# Altium schematic sheet W702Dev.SchDoc
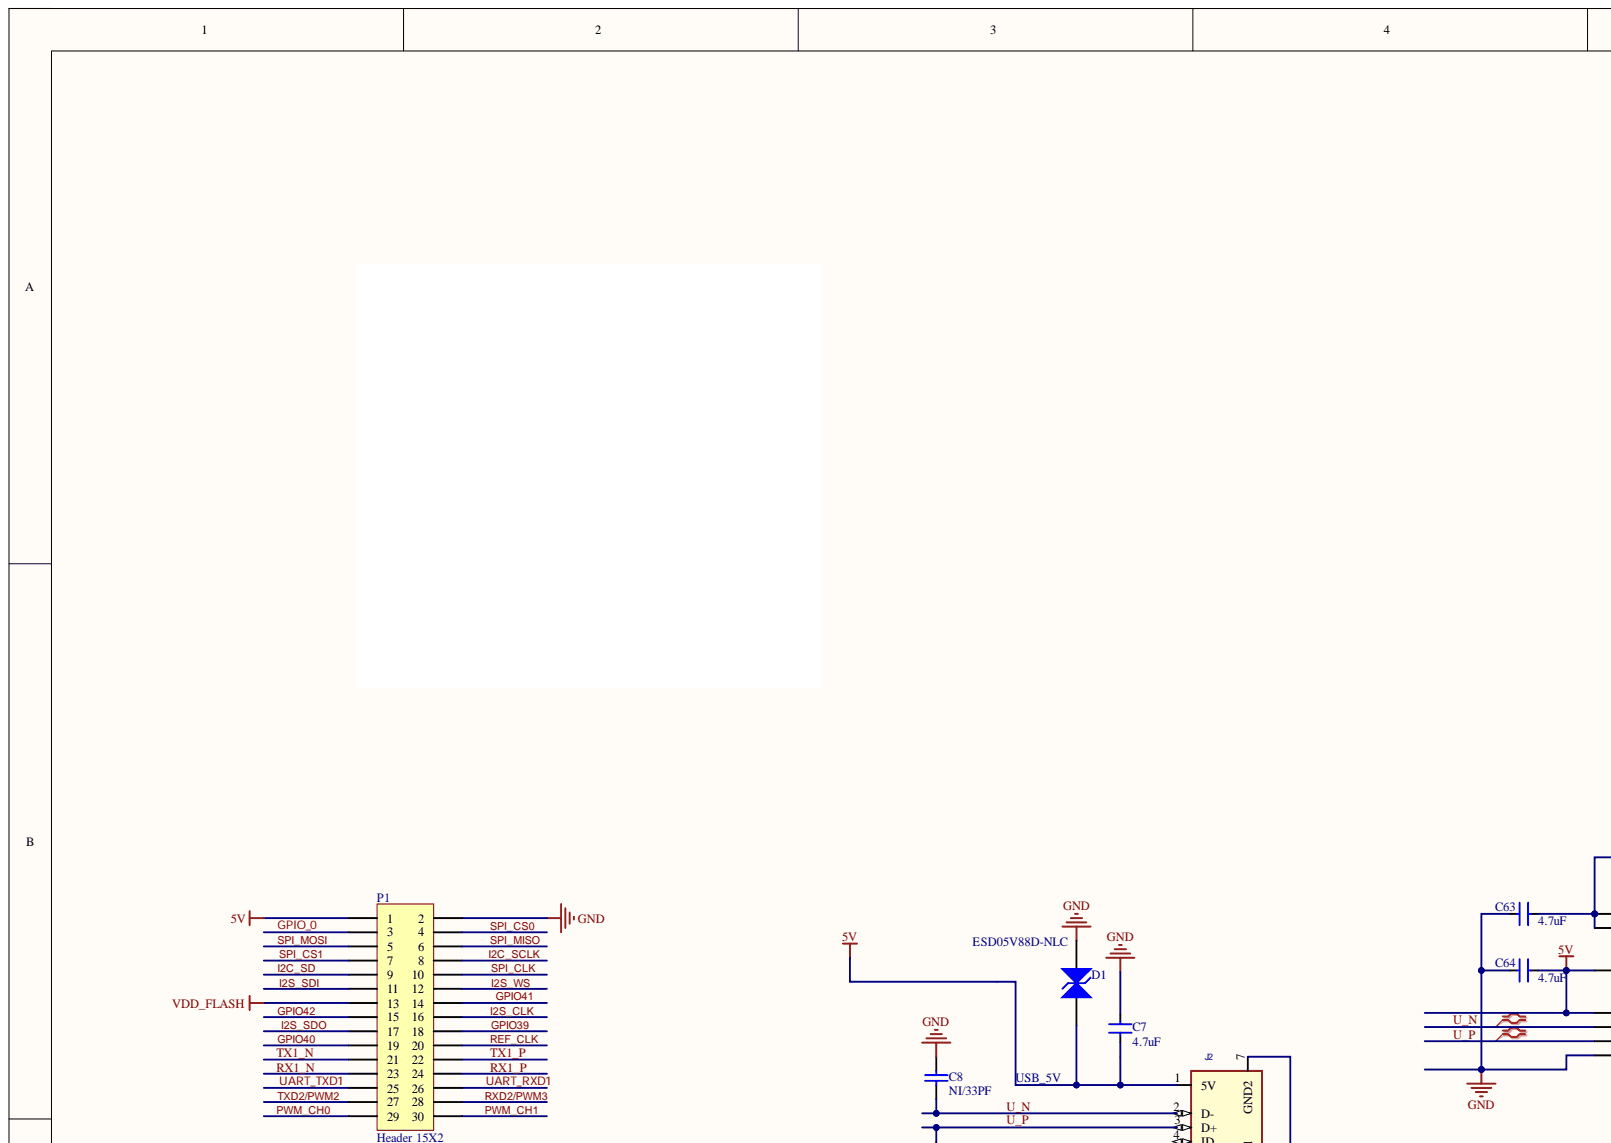
[diagram p0: W702Dev.SchDoc, top left]
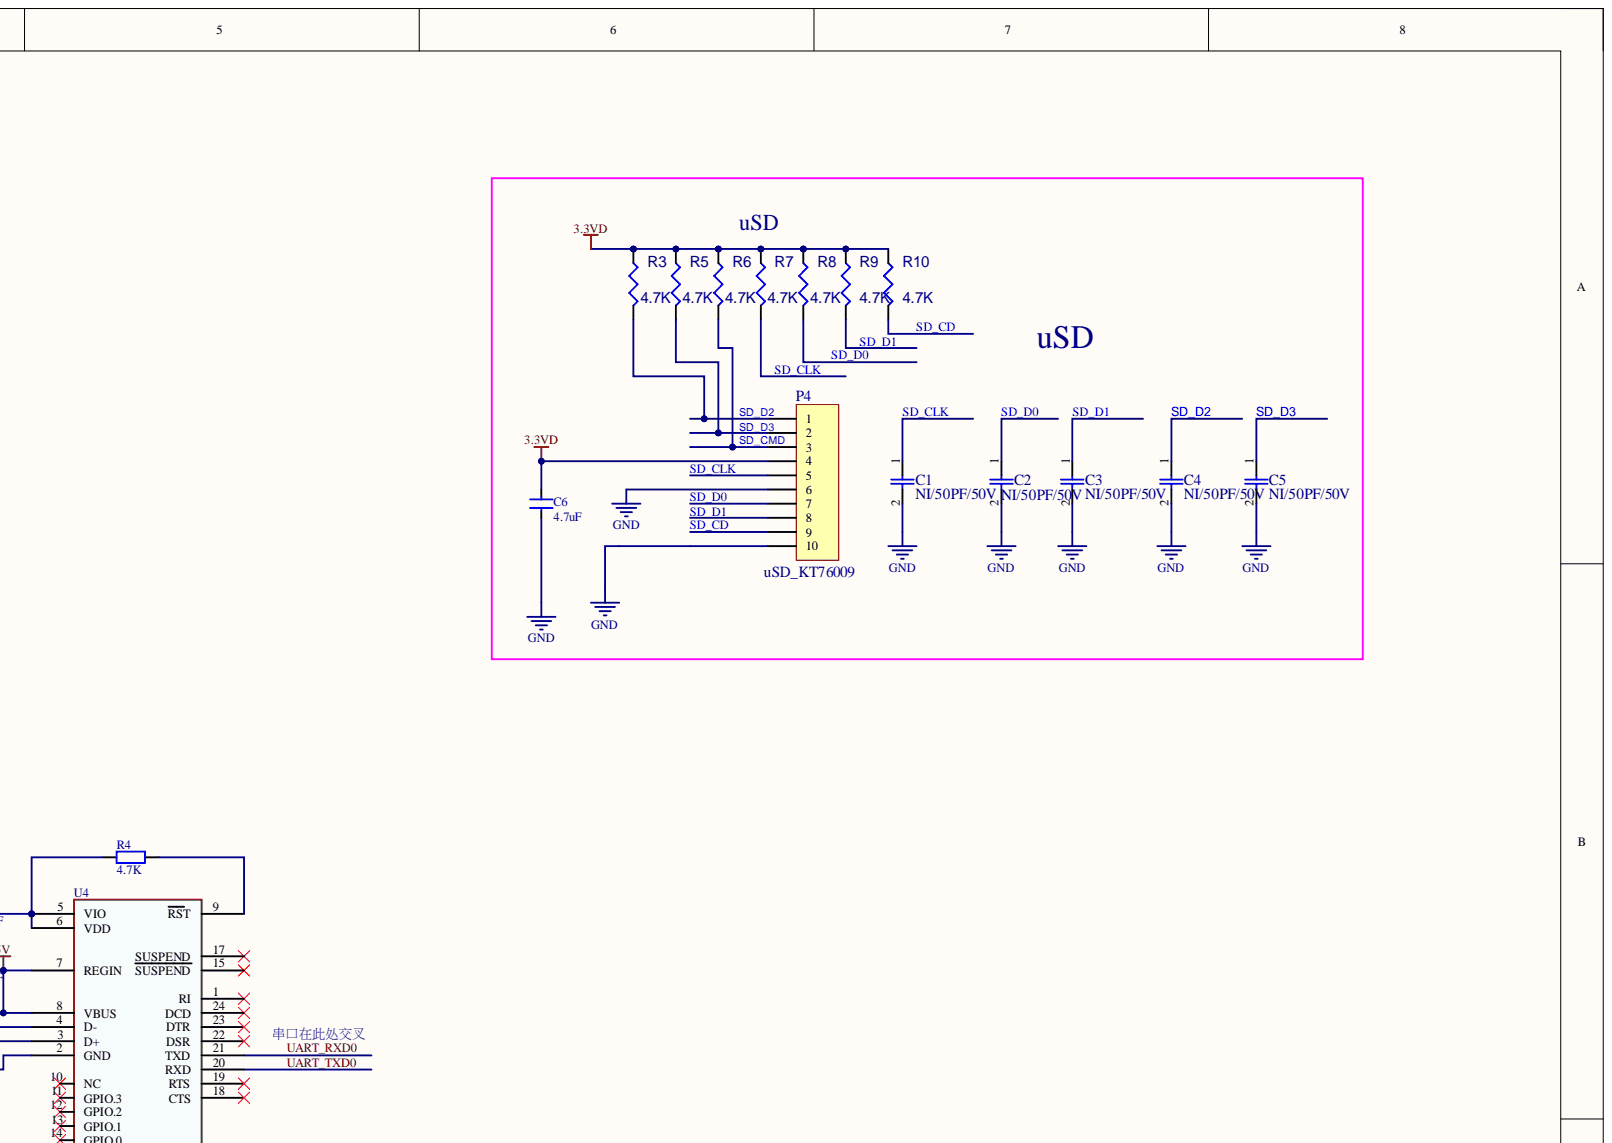
[diagram p1: W702Dev.SchDoc, top right]
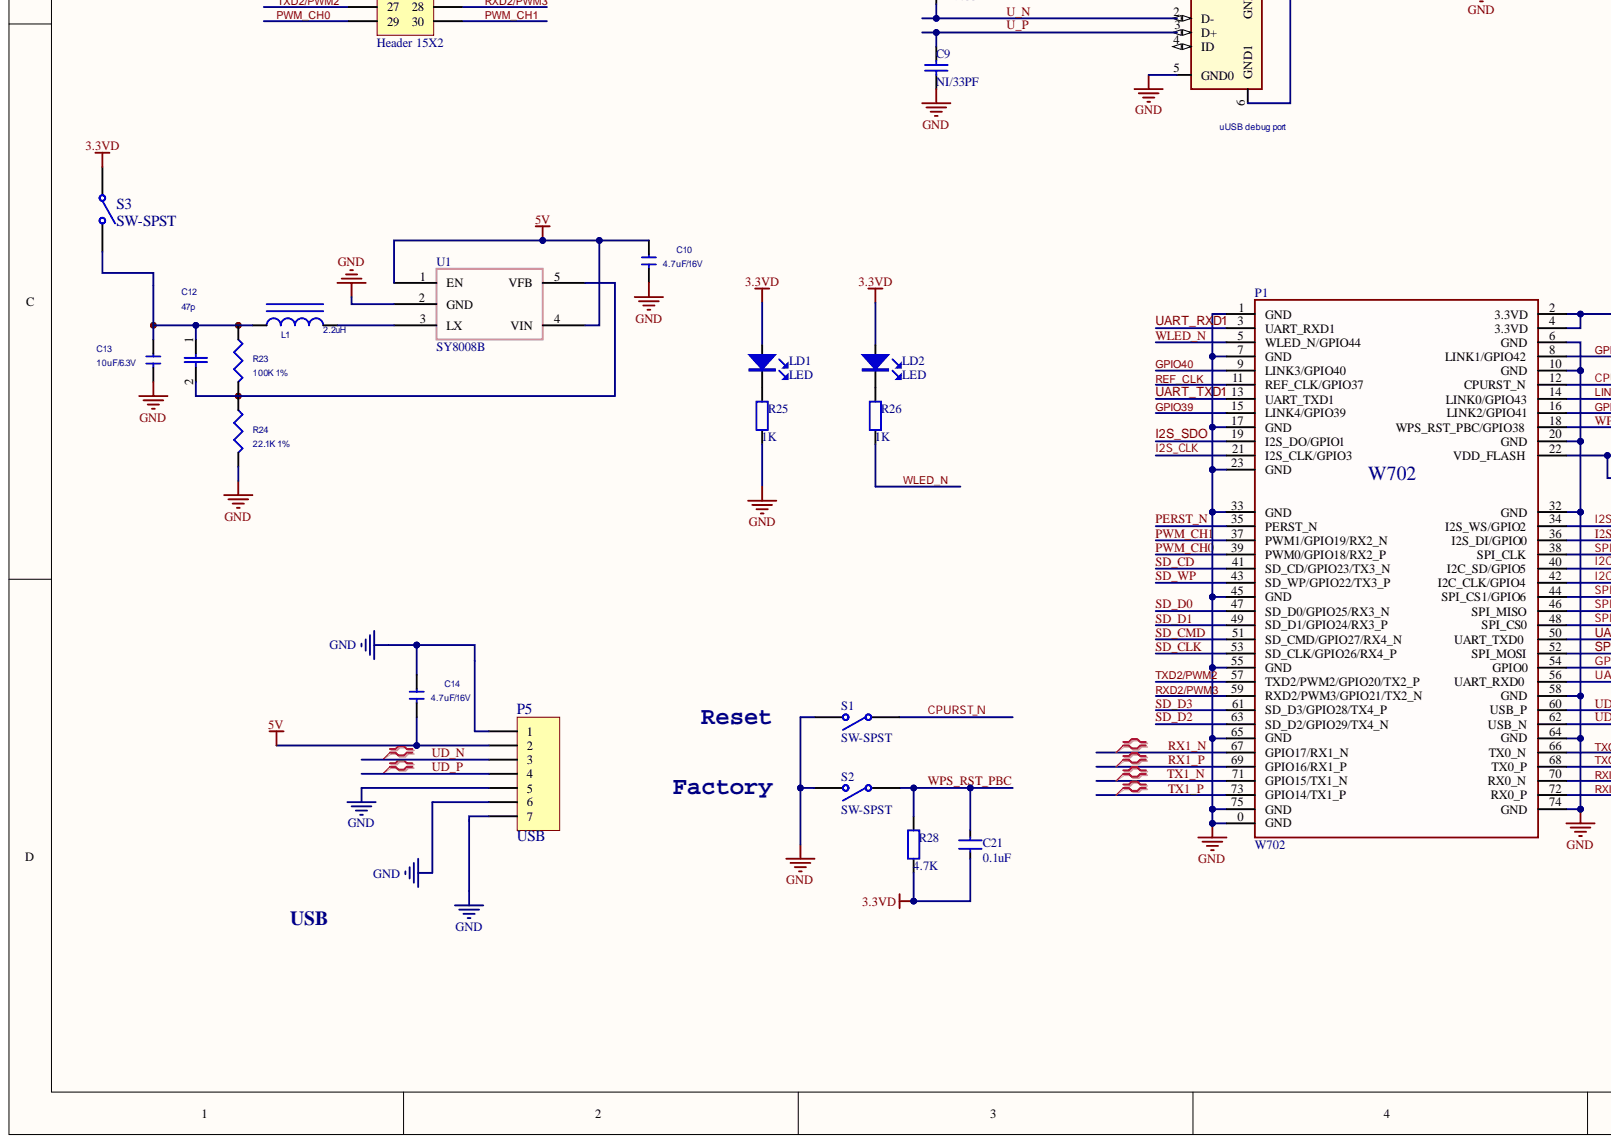
[diagram p2: W702Dev.SchDoc, bottom left]
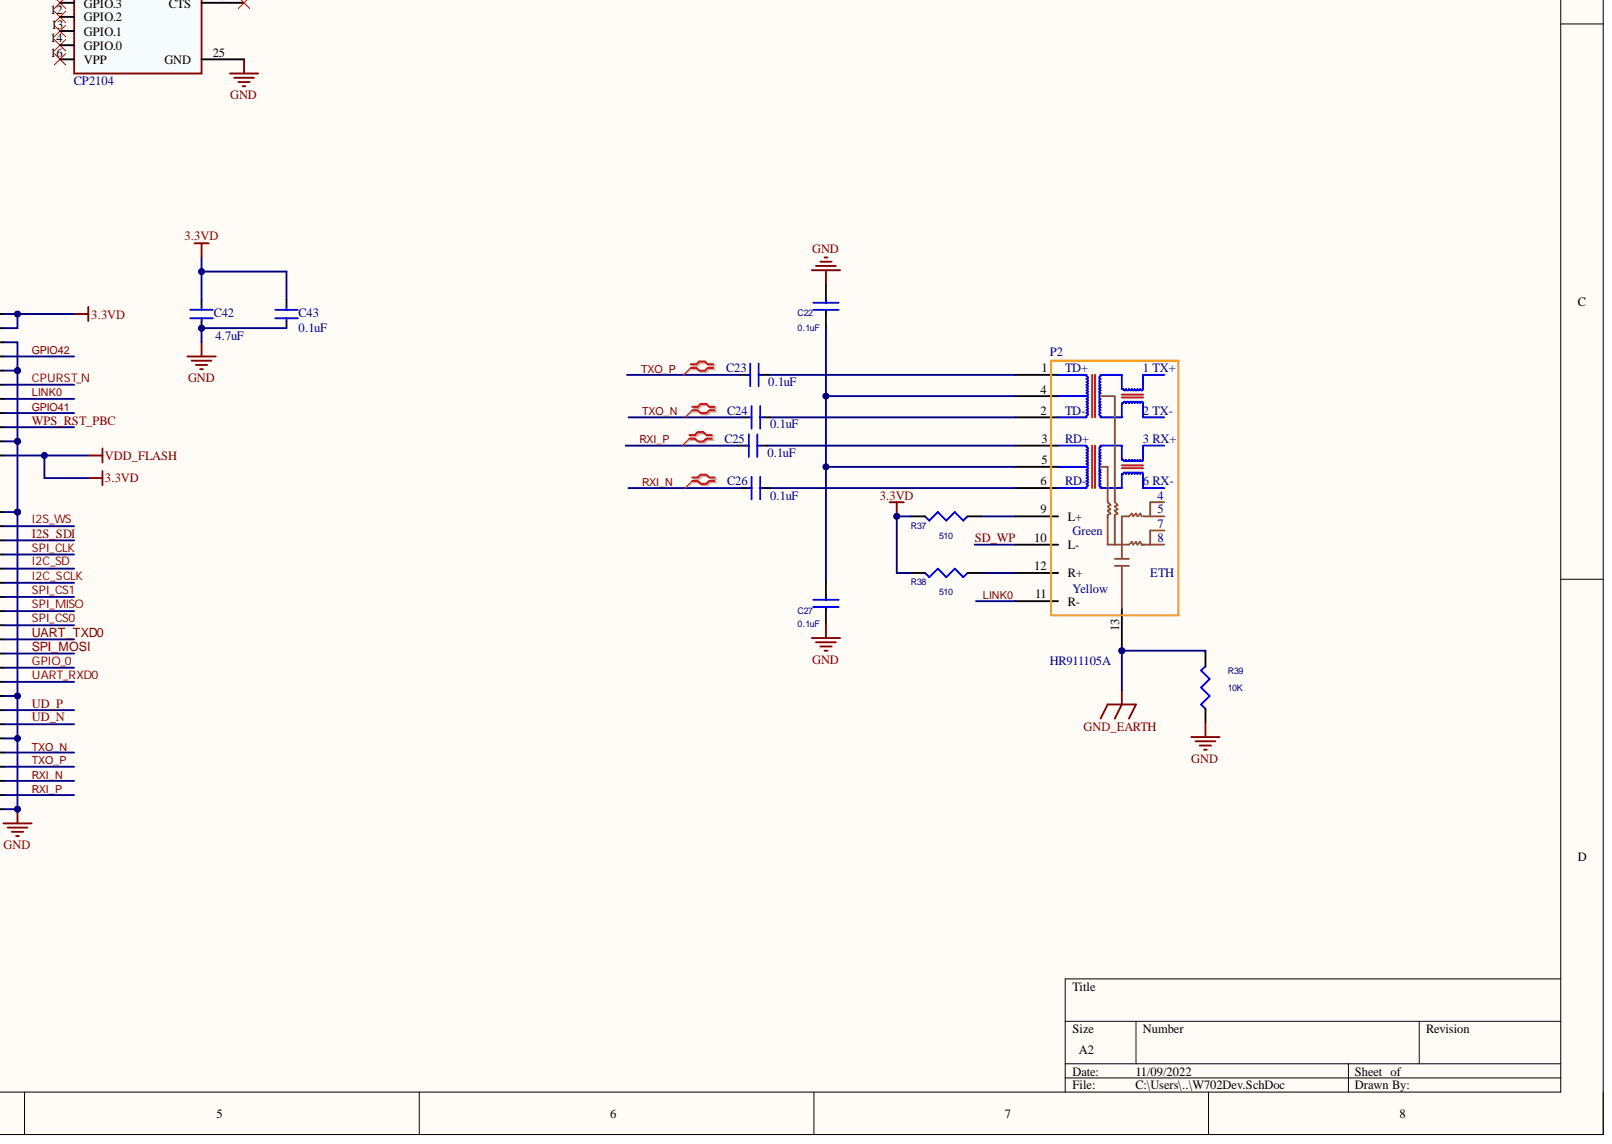
[diagram p3: W702Dev.SchDoc, bottom right]

## Parts
C1  NI/50PF/50V  [C0402]
C2  NI/50PF/50V  [C0402]
C3  NI/50PF/50V  [C0402]
C4  NI/50PF/50V  [C0402]
C5  NI/50PF/50V  [C0402]
C6  4.7uF  [C0402]
C7  4.7uF  [C0402]
C8  NI/33PF  [C0402]
C9  NI/33PF  [C0402]
C10  4.7uF/16V  [C0603]
C12  47p  [C0402]
C13  10uF/6.3V  [C0603]
C14  4.7uF/16V  [C0603]
C21  0.1uF  [C0402]
C22  0.1uF  [C0402]
C23  0.1uF  [C0402]
C24  0.1uF  [C0402]
C25  0.1uF  [C0402]
C26  0.1uF  [C0402]
C27  0.1uF  [C0402]
C42  4.7uF  [C0402]
C43  0.1uF  [C0402]
C63  4.7uF  [C0402]
C64  4.7uF  [C0402]
D1  ESD05V88D-NLC  [D0034]
J2  uUSB debug port  [microUSB]
L1  2.2uH  [l2512]
LD1  LED  [LED_0603]
LD2  LED  [LED_0603]
P1  Header 15X2  [HDR2X15]
P1  W702
P2  HR911105A  [P0029]
P4  uSD_KT76009  [uSD_KT76009]
P5  USB  [USB A母 90度側插]
R3  4.7K  [R0402]
R4  4.7K  [R0402]
R5  4.7K  [R0402]
R6  4.7K  [R0402]
R7  4.7K  [R0402]
R8  4.7K  [R0402]
R9  4.7K  [R0402]
R10  4.7K  [R0402]
R23  100K 1%  [R0402]
R24  22.1K 1%  [R0402]
R25  1K  [R0402]
R26  1K  [R0402]
R28  4.7K  [R0402]
R37  510  [R0402]
R38  510  [R0402]
R39  10K  [R0402]
S1  SW-SPST  [P0066]
S2  SW-SPST  [P0066]
S3  SW-SPST  [按件開關2PIN_DIP]
U1  SY8008B  [SOT23-5]
U4  CP2104  [QFN24]

## Nets
3.3VD: C6.1 C21.1 C42.1 C43.1 LD1.A LD2.A P1.2 P1.4 P1.13 P1.22 P4.4 R3.1 R5.1 R6.1 R7.1 R8.1 R9.1 R10.1 R28.2 R37.2 R38.2 S3.1
GND: C1.2 C2.2 C3.2 C4.2 C5.2 C6.2 C7.1 C8.1 C9.1 C10.2 C13.2 C14.1 C22.2 C27.1 C42.2 C43.2 C63.2 C64.1 D1.1 J2.5(GND0) P1.0(GND) P1.1 P1.2 P1.6 P1.7 P1.10 P1.17 P1.20 P1.23 P1.32(GND) P1.33(GND) P1.45(GND) P1.55(GND) P1.58(GND) P1.64(GND) P1.65(GND) P1.74(GND) P1.75(GND) P4.6 P4.10 P5.1 P5.5 P5.6 P5.7 R24.2 R25.2 R39.1 S1.2 S2.2 U1.2(GND) U4.2(GND) U4.25(GND)
GND_EARTH: P2.13 R39.2
CPURST_N: P1.12 S1.1
GPIO39: P1.15 P1.18
GPIO40: P1.9 P1.19
GPIO41: P1.14 P1.16
GPIO42: P1.8 P1.15
GPIO_0: P1.3 P1.54(GPIO0)
I2C_SCLK: P1.8 P1.42(I2C_CLK/GPIO4)
I2C_SD: P1.9 P1.40(I2C_SD/GPIO5)
I2S_CLK: P1.16 P1.21
I2S_SDI: P1.11 P1.36(I2S_DI/GPIO0)
I2S_SDO: P1.17 P1.19
I2S_WS: P1.12 P1.34(I2S_WS/GPIO2)
LINK0: P1.14 P2.11(R-)
PERST_N: P1.35(PERST_N)
PWM_CH0: P1.29 P1.39(PWM0/GPIO18/RX2_P)
PWM_CH1: P1.30 P1.37(PWM1/GPIO19/RX2_N)
REF_CLK: P1.11 P1.20
RX1_N: P1.23 P1.67(GPIO17/RX1_N)
RX1_P: P1.24 P1.69(GPIO16/RX1_P)
RXD2/PWM3: P1.28 P1.59(RXD2/PWM3/GPIO21/TX2_N)
RXI_N: C26.1 P1.70(RX0_N)
RXI_P: C25.1 P1.72(RX0_P)
SD_CD: P1.41(SD_CD/GPIO23/TX3_N) P4.9 R10.2
SD_CLK: C1.1 P1.53(SD_CLK/GPIO26/RX4_P) P4.5 R7.2
SD_CMD: P1.51(SD_CMD/GPIO27/RX4_N) P4.3 R6.2
SD_D0: C2.1 P1.47(SD_D0/GPIO25/RX3_N) P4.7 R8.2
SD_D1: C3.1 P1.49(SD_D1/GPIO24/RX3_P) P4.8 R9.2
SD_D2: C4.1 P1.63(SD_D2/GPIO29/TX4_N) P4.1 R3.2
SD_D3: C5.1 P1.61(SD_D3/GPIO28/TX4_P) P4.2 R5.2
SD_WP: P1.43(SD_WP/GPIO22/TX3_P) P2.10(L-)
SPI_CLK: P1.10 P1.38(SPI_CLK)
SPI_CS0: P1.4 P1.48(SPI_CS0)
SPI_CS1: P1.7 P1.44(SPI_CS1/GPIO6)
SPI_MISO: P1.6 P1.46(SPI_MISO)
SPI_MOSI: P1.5 P1.52(SPI_MOSI)
TX1_N: P1.21 P1.71(GPIO15/TX1_N)
TX1_P: P1.22 P1.73(GPIO14/TX1_P)
TXD2/PWM2: P1.27 P1.57(TXD2/PWM2/GPIO20/TX2_P)
TXO_N: C24.1 P1.66(TX0_N)
TXO_P: C23.1 P1.68(TX0_P)
U_N: C8.2 J2.2(D-) U4.4(D-)
U_P: C9.2 J2.3(D+) U4.3(D+)
UART_RXD0: P1.56(UART_RXD0) U4.21(TXD)
UART_RXD1: P1.3 P1.26
UART_TXD0: P1.50(UART_TXD0) U4.20(RXD)
UART_TXD1: P1.13 P1.25
UD_N: P1.62(USB_N) P5.3
UD_P: P1.60(USB_P) P5.4
USB_5V: C7.2 C10.1 C14.2 C64.2 D1.2 J2.1(5V) P1.1 P5.2 U1.1(EN) U1.4(VIN) U4.7(REGIN) U4.8(VBUS)
WLED_N: P1.5 R26.2
WPS_RST_PBC: C21.2 P1.18 R28.1 S2.1
NetC12_1: C12.1 C13.1 L1.1 R23.1 S3.2
NetC12_2: C12.2 R23.2 R24.1 U1.5(VFB)
NetC22_1: C22.1 C27.2 P2.4 P2.5
NetC23_2: C23.2 P2.1
NetC24_2: C24.2 P2.2
NetC25_2: C25.2 P2.3
NetC26_2: C26.2 P2.6
NetC63_1: C63.1 R4.1 U4.5(VIO) U4.6(VDD)
NetJ2_6: J2.6(GND1) J2.7(GND2)
NetL1_2: L1.2 U1.3(LX)
NetLD1_K: LD1.K R25.1
NetLD2_K: LD2.K R26.1
NetP2_9: P2.9(L+) R37.1
NetP2_12: P2.12(R+) R38.1
NetR4_2: R4.2 U4.9(RST)

Not connected: J2 4(ID); U4 1(RI) 10(NC) 11(GPIO.3) 12(GPIO.2) 13(GPIO.1) 14(GPIO.0) 15(SUSPEND) 16(VPP) 17(SUSPEND) 18(CTS) 19(RTS) 22(DSR) 23(DTR) 24(DCD)

## Library data of the parts
C1-C5 = C0402: Capacitor; Component Kind: Standard
C6 C7 C42 C63 C64 = CAP: 普通小电容; 料號: C0023; Component Kind: Standard
C8 C9 = CAP_1: Capacitor; Value: 100pF; Component Kind: Standard; Published: 8-Jun-2000; Revision: July-2002: Re-released for DXP Platform.; Signal Integrity: Cap; Simulation: CAP; Publisher: Altium Limited
C10 C14 = MLCC 22uF 6_1.3V 20% 0603 SMD: 料號: C0076; Component Kind: Standard
C12 = CAP/0402_1: 料號: C0065; Component Kind: Standard
C13 = MLCC 22uF 6_1.3V 20% 0603 SMD: 料號: C0025; Component Kind: Standard
C21 C23-C26 C43 = CAP: 普通小电容; 料號: C0006; Component Kind: Standard
C22 C27 = CAPACITOR NON-POL: 料號: C0006; Component Kind: Standard
D1 = D0034: bidirectional, 5V, 1.0*0.6, 0.25pF; Component Kind: Standard; ComponentLink1Description: 供应商链接; ComponentLink1URL: http://www.szlcsc.com/product/details_79530.html; Library Name: D0034.SchLib; Package: SMB/DO-214AA; Supplier: LC; SuppliersPartNumber: C78395; 料號: D0034
J2 = CONN Micro USB SMD: Part number: 10B119650501; 料號: P0009; Component Kind: Standard
L1 = IND2.2uH/1KHZ 1.8A 20% 3D18 SMD: 料號: L0010; Component Kind: Standard
LD1 LD2 = LED: Light-Emitting Diode; 料號: D0018; Component Kind: Standard; Library Name: ST_Lib3D.SchLib
P1 = Header 15X2: Header, 15-Pin, Dual row; Component Kind: Standard; LatestRevisionDate: 17-Jul-2002; LatestRevisionNote: Re-released for DXP Platform.; Library Name: LIB_MANGO.IntLib; Publisher: Altium Limited
P4 = Header 10: Component Kind: Standard; Description: uSD_KT76009; LatestRevisionDate: 17-Jul-2002; LatestRevisionNote: Re-released for DXP Platform.; Library Name: Miscellaneous Connectors.IntLib; Signal Integrity: Connector
P5 = Header 7: USB A TYPE, 7-Pin; 料號: P0055; Component Kind: Standard; LatestRevisionNote: Re-released for DXP Platform.; Library Name: Miscellaneous Connectors.IntLib; Publisher: Altium Limited
R3 R5-R10 = RES 1K 1% 1/16W 0402 SMD: Resistor; 料號: R0007; Component Kind: Standard; PCB DECAL: R0402; Value: 4.7K
R4 R28 = RES: 普通电阻; 料號: R0007; Component Kind: Standard
R23 = RES 220K 5% 1/16W 0402 SMD: 料號: R0029; Component Kind: Standard
R24 = RES 49K9 1% 1/16W 0603 SMD: 料號: R0121; Component Kind: Standard
R25 R26 = RES: 普通电阻; 料號: R000R; Component Kind: Standard
R37 R38 = R: 料號: R0069; Component Kind: Standard
R39 = RESISTOR: 料號: R0050; Component Kind: Standard
S1 S2 = SW-SPST: Single-Pole, Single-Throw Switch; Component Kind: Standard; Library Name: Miscellaneous Devices.IntLib; 料號: P0066
S3 = SW-SPST: POWER SW; Component Kind: Standard; Library Name: Miscellaneous Devices.IntLib
U1 = G5728: 料號: U0010; Component Kind: Standard; Library Name: IC.SchLib
P1 = W702: Component Kind: Standard; Library Name: BIT345.SchLib
P2 = HR911105A: Component Kind: Standard; Library Name: Schlib1.Lib; 料號: P0029
U4 = CP2104: Component Kind: Standard; Library Name: LIB_MANGO.IntLib
